# Altium schematic sheet uC_IO.SchDoc
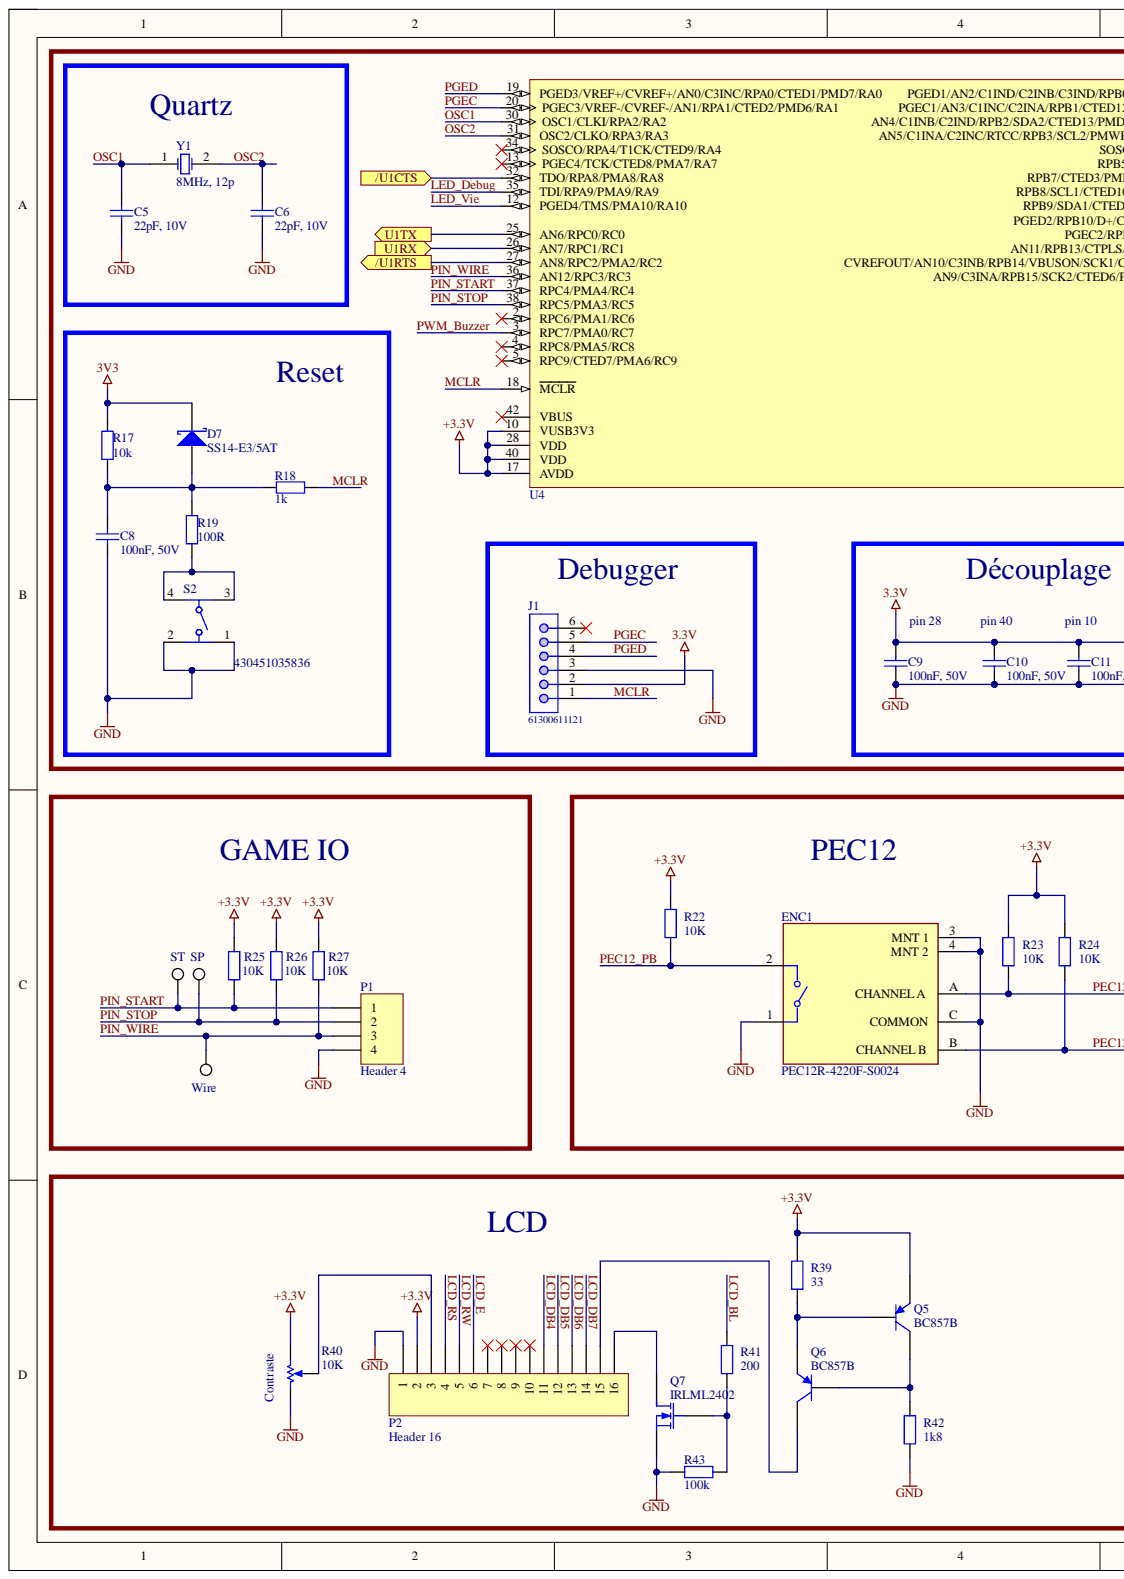
[diagram p0: uC_IO.SchDoc, left]
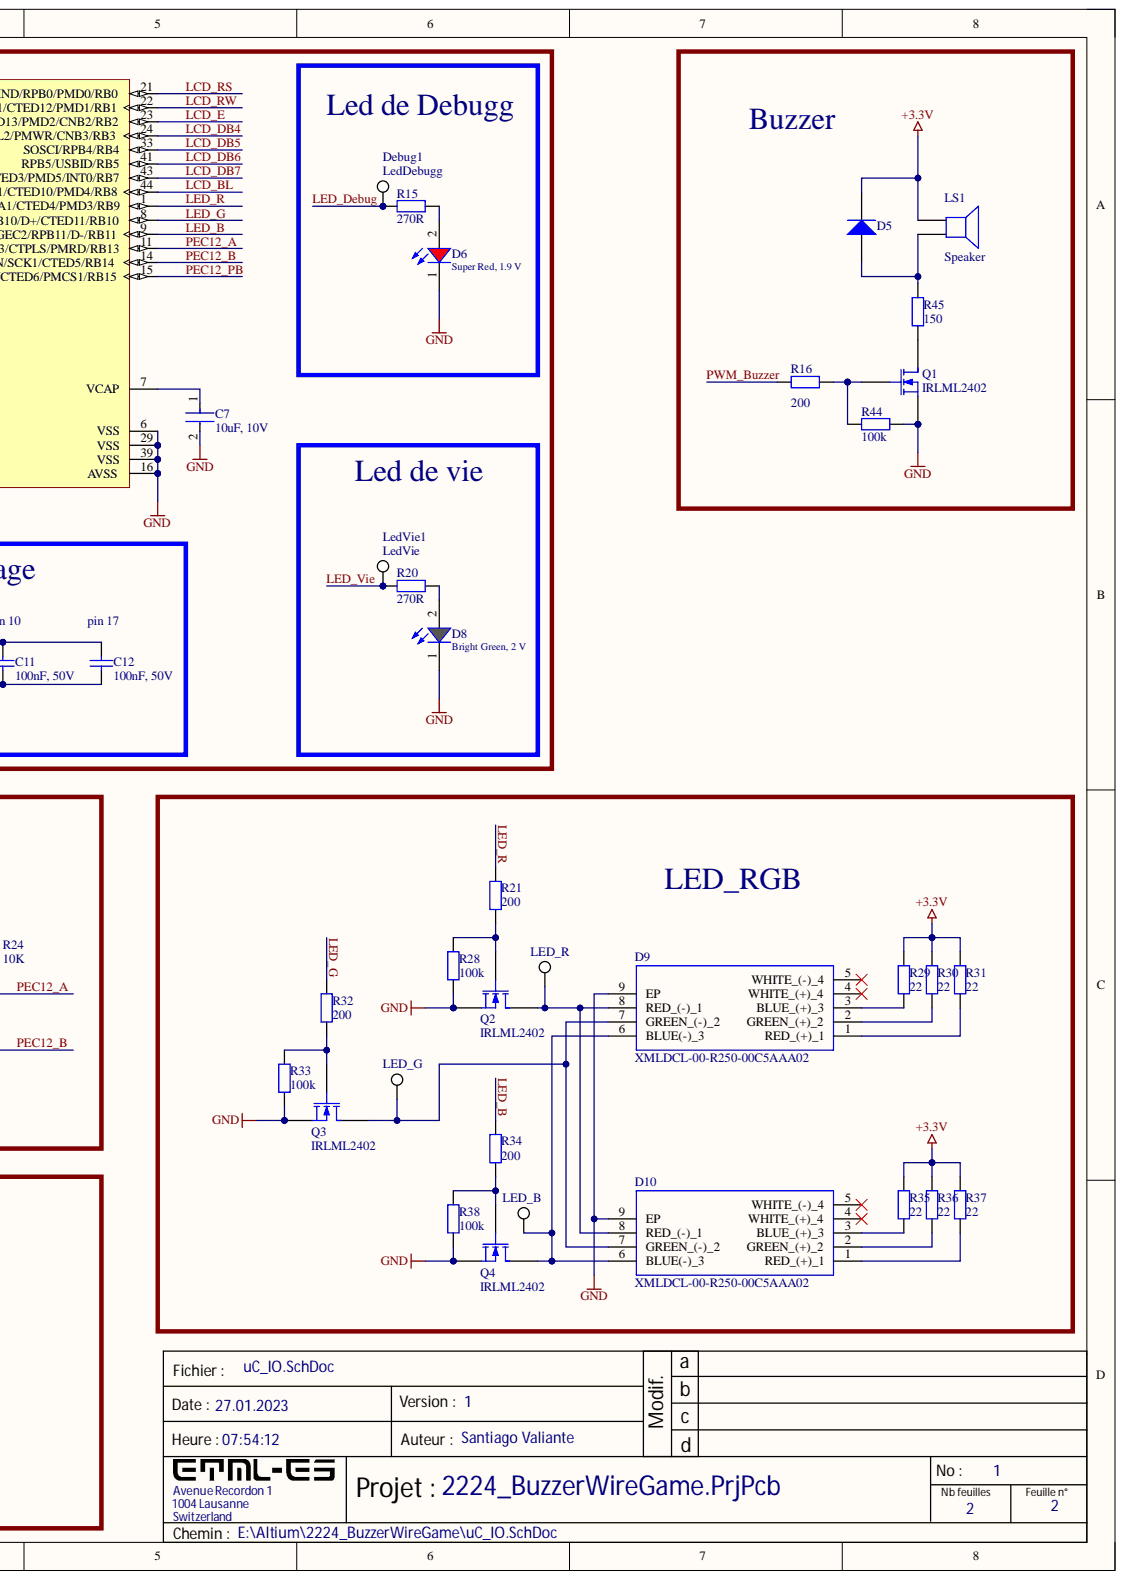
[diagram p1: uC_IO.SchDoc, right]

## Parts
C5  22pF, 10V  [CAPC2012M]
C6  22pF, 10V  [CAPC2012M]
C7  10uF, 10V  Voltage: 10V  [CAPC2012M]
C8  100nF, 50V  [CAPC2012M]
C9  100nF, 50V  [CAPC2012M]
C10  100nF, 50V  [CAPC2012M]
C11  100nF, 50V  [CAPC2012M]
C12  100nF, 50V  [CAPC2012M]
D5  Diode 1N4007  [DO-41]  (value not drawn)
D6  Super Red, 1.9 V  [WL-SMCW_0805]
D7  SS14-E3/5AT  [VISH-DO-214AC-2_V]
D8  Bright Green, 2 V  [WL-SMCW_0805]
D9  XMLDCL-00-R250-00C5AAA02  [XMLDCL00R25000C5AAA02]
D10  XMLDCL-00-R250-00C5AAA02  [XMLDCL00R25000C5AAA02]
Debug1  LedDebugg  [KSTN-5019_V]
ENC1  PEC12R-4220F-S0024  [FP-PEC12R-4220F-S0024-MFG]
J1  61300611121  [61300611121]
LED_B  Vbat  [KSTN-5019_V]  (value not drawn)
LED_G  Vbat  [KSTN-5019_V]  (value not drawn)
LED_R  Vbat  [KSTN-5019_V]  (value not drawn)
LedVie1  LedVie  [KSTN-5019_V]
LS1  Speaker  [PIN2]
P1  Header 4  [HDR1X4]
P2  Header 16  [HDR1X16]
Q1  IRLML2402  [E3]
Q2  IRLML2402  [E3]
Q3  IRLML2402  [E3]
Q4  IRLML2402  [E3]
Q5  BC857B  [SOT-23B_N]
Q6  BC857B  [SOT-23B_N]
Q7  IRLML2402  [E3]
R15  270R  [RESC2012M]
R16  value 200  [AXIAL-0.4]  (hidden comment: Res2)
R17  10k  [RESC2012M]
R18  1k  [RESC2012M]
R19  100R  [RESC2012M]
R20  270R  [RESC2012M]
R21  value 200  [AXIAL-0.4]  (hidden comment: Res2)
R22  value 10K  [AXIAL-0.4]  (hidden comment: Res2)
R23  value 10K  [AXIAL-0.4]  (hidden comment: Res2)
R24  value 10K  [AXIAL-0.4]  (hidden comment: Res2)
R25  value 10K  [AXIAL-0.4]  (hidden comment: Res2)
R26  value 10K  [AXIAL-0.4]  (hidden comment: Res2)
R27  value 10K  [AXIAL-0.4]  (hidden comment: Res2)
R28  value 100k  [AXIAL-0.4]  (hidden comment: Res2)
R29  value 22  [AXIAL-0.4]  (hidden comment: Res2)
R30  value 22  [AXIAL-0.4]  (hidden comment: Res2)
R31  value 22  [AXIAL-0.4]  (hidden comment: Res2)
R32  value 200  [AXIAL-0.4]  (hidden comment: Res2)
R33  value 100k  [AXIAL-0.4]  (hidden comment: Res2)
R34  value 200  [AXIAL-0.4]  (hidden comment: Res2)
R35  value 22  [AXIAL-0.4]  (hidden comment: Res2)
R36  value 22  [AXIAL-0.4]  (hidden comment: Res2)
R37  value 22  [AXIAL-0.4]  (hidden comment: Res2)
R38  value 100k  [AXIAL-0.4]  (hidden comment: Res2)
R39  value 33  [AXIAL-0.4]  (hidden comment: Res2)
R40  value 10K  [VR5]  (hidden comment: RPot)
R41  value 200  [AXIAL-0.4]  (hidden comment: Res2)
R42  value 1k8  [AXIAL-0.4]  (hidden comment: Res2)
R43  value 100k  [AXIAL-0.4]  (hidden comment: Res2)
R44  value 100k  [AXIAL-0.4]  (hidden comment: Res2)
R45  value 150  [AXIAL-0.4]  (hidden comment: Res2)
S2  430451035836  [430451035836]
SP  Vbat  [KSTN-5019_V]  (value not drawn)
ST  Vbat  [KSTN-5019_V]  (value not drawn)
U4  PIC32MX250F128D-I/PT  [MCHP-TQFP-PT44_M]  (value not drawn)
Wire  Vbat  [KSTN-5019_V]  (value not drawn)
Y1  8MHz, 12p  [FOX-FOXSDLF160-20_V]

## Ports
/U1CTS, /U1RTS, U1RX, U1TX

## Nets
3.3V: C9.1 C10.1 C11.1 C12.1 D5.2 J1.2 LS1.1 P2.2 Q5.3 R22.2 R23.2 R24.2 R25.2 R26.2 R27.2 R29.1 R30.1 R31.1 R35.1 R36.1 R37.1 R39.2 R40.1 U4.10(VUSB3V3) U4.17(AVDD) U4.28(VDD) U4.40(VDD)
3V3: D7.2 R17.2
GND: C5.1 C6.1 C7.2 C8.2 C9.2 C10.2 C11.2 C12.2 D6.1 D8.1 D9.9(EP) D10.9(EP) ENC1.1 ENC1.3(MNT_1) ENC1.4(MNT_2) ENC1.C(COMMON) J1.3 P1.4 P2.1 Q1.3 Q2.3 Q3.3 Q4.3 Q7.3 R28.2 R33.2 R38.2 R40.2 R42.1 R43.2 R44.2 S2.1 S2.2 U4.6(VSS) U4.16(AVSS) U4.29(VSS) U4.39(VSS)
/U1CTS: U4.32(TDO/RPA8/PMA8/RA8) ; port /U1CTS
/U1RTS: U4.27(AN8/RPC2/PMA2/RC2) ; port /U1RTS
LCD_BL: R41.2 U4.44(RPB8/SCL1/CTED10/PMD4/RB8)
LCD_DB4: P2.11 U4.24(AN5/C1INA/C2INC/RTCC/RPB3/SCL2/PMWR/CNB3/RB3)
LCD_DB5: P2.12 U4.33(SOSCI/RPB4/RB4)
LCD_DB6: P2.13 U4.41(RPB5/USBID/RB5)
LCD_DB7: P2.14 U4.43(RPB7/CTED3/PMD5/INT0/RB7)
LCD_E: P2.6 U4.23(AN4/C1INB/C2IND/RPB2/SDA2/CTED13/PMD2/CNB2/RB2)
LCD_RS: P2.4 U4.21(PGED1/AN2/C1IND/C2INB/C3IND/RPB0/PMD0/RB0)
LCD_RW: P2.5 U4.22(PGEC1/AN3/C1INC/C2INA/RPB1/CTED12/PMD1/RB1)
LED_B: R34.2 U4.9(PGEC2/RPB11/D-/RB11)
LED_Debug: Debug1.1 R15.2 U4.35(TDI/RPA9/PMA9/RA9)
LED_G: R32.2 U4.8(PGED2/RPB10/D+/CTED11/RB10)
LED_R: R21.2 U4.1(RPB9/SDA1/CTED4/PMD3/RB9)
LED_Vie: LedVie1.1 R20.2 U4.12(PGED4/TMS/PMA10/RA10)
MCLR: J1.1 R18.2 U4.18(MCLR)
OSC1: C5.2 U4.30(OSC1/CLKI/RPA2/RA2) Y1.1
OSC2: C6.2 U4.31(OSC2/CLKO/RPA3/RA3) Y1.2
PEC12_A: ENC1.A(CHANNEL_A) R23.1 U4.11(AN11/RPB13/CTPLS/PMRD/RB13)
PEC12_B: ENC1.B(CHANNEL_B) R24.1 U4.14(CVREFOUT/AN10/C3INB/RPB14/VBUSON/SCK1/CTED5/RB14)
PEC12_PB: ENC1.2 R22.1 U4.15(AN9/C3INA/RPB15/SCK2/CTED6/PMCS1/RB15)
PGEC: J1.5 U4.20(PGEC3/VREF-/CVREF-/AN1/RPA1/CTED2/PMD6/RA1)
PGED: J1.4 U4.19(PGED3/VREF+/CVREF+/AN0/C3INC/RPA0/CTED1/PMD7/RA0)
PIN_START: P1.1 R25.1 ST.1 U4.37(RPC4/PMA4/RC4)
PIN_STOP: P1.2 R26.1 SP.1 U4.38(RPC5/PMA3/RC5)
PIN_WIRE: P1.3 R27.1 U4.36(AN12/RPC3/RC3) Wire.1
PWM_Buzzer: R16.1 U4.3(RPC7/PMA0/RC7)
U1RX: U4.26(AN7/RPC1/RC1) ; port U1RX
U1TX: U4.25(AN6/RPC0/RC0) ; port U1TX
NetC7_1: C7.1 U4.7(VCAP)
NetC8_1: C8.1 D7.1 R17.1 R18.1 R19.2
NetD5_1: D5.1 LS1.2 R45.1
NetD6_2: D6.2 R15.1
NetD8_2: D8.2 R20.1
NetD9_1: D9.1(RED_(+)_1) R31.2
NetD9_2: D9.2(GREEN_(+)_2) R30.2
NetD9_3: D9.3(BLUE_(+)_3) R29.2
NetD9_6: D9.6(BLUE(-)_3) D10.6(BLUE(-)_3) LED_B.1 Q4.1
NetD9_7: D9.7(GREEN_(-)_2) D10.7(GREEN_(-)_2) LED_G.1 Q3.1
NetD9_8: D9.8(RED_(-)_1) D10.8(RED_(-)_1) LED_R.1 Q2.1
NetD10_1: D10.1(RED_(+)_1) R37.2
NetD10_2: D10.2(GREEN_(+)_2) R36.2
NetD10_3: D10.3(BLUE_(+)_3) R35.2
NetP2_3: P2.3 R40.3
NetP2_15: P2.15 Q6.1
NetP2_16: P2.16 Q7.1
NetQ1_1: Q1.1 R45.2
NetQ1_2: Q1.2 R16.2 R44.1
NetQ2_2: Q2.2 R21.1 R28.1
NetQ3_2: Q3.2 R32.1 R33.1
NetQ4_2: Q4.2 R34.1 R38.1
NetQ5_1: Q5.1 Q6.2 R42.2
NetQ5_2: Q5.2 Q6.3 R39.1
NetQ7_2: Q7.2 R41.1 R43.1
NetR19_1: R19.1 S2.3 S2.4

Not connected: D9 4(WHITE_(+)_4) 5(WHITE_(-)_4); D10 4(WHITE_(+)_4) 5(WHITE_(-)_4); J1 6; P2 7 8 9 10; U4 2(RPC6/PMA1/RC6) 4(RPC8/PMA5/RC8) 5(RPC9/CTED7/PMA6/RC9) 13(PGEC4/TCK/CTED8/PMA7/RA7) 34(SOSCO/RPA4/T1CK/CTED9/RA4) 42(VBUS)

## Library data of the parts
C5 C6 = CMP-1036-01211-1: CAP 22pF 10V ±10% 0805 (2012 Metric) Thickness 0.75mm SMD; Case-EIA: 0805; Case-Metric: 2012; Tolerance: Â±10%; Component Kind: Standard; Max Thickness: 0.75 mm; Rated Voltage: 10 V; Technology: SMT; Value: 22pF; Library Name: 2121_Robot3roues_V2.0.SCHLIB
C7 = CMP-2007-03243-2: CAP CER 10UF 10V X5R 0805; 3DS Part Number: 220-00001; Manufacturer: Kemet; Manufacturer Part Number: C0805C106K8PACTU; Material: Ceramic; Temperature Coefficient: 15%; Tolerance: 10%; Automotive: No; Capacitance: 10uF; Category: Cap; Component Kind: Standard; ComponentLink2Description: Datasheet; ComponentLink2URL: https://content.kemet.com/datasheets/KEM_C1006_X5R_SMD.pdf; Device Class L1: Passive Components; Device Class L2: Capacitors; Device Class L3: Ceramic Capacitors; Digikey Description: CAP CER 10UF 10V X5R 0805; Height: 1.4mm; Ipc Land Pattern Name: CAPC200125X125; Lead Free: Yes; Mouser Description: CAP CER 10UF 10V X5R 0805; Octopart: https://octopart.com/c0805c106k8pactu-kemet-98697; Package: C0805C-DG; RoHS: TRUE; Temperature: -55°C to +85°C; Temperature Characteristic: X5R; Temperature Range High: +85°C; Temperature Range Low: -55°C; Value: 10uF; Voltage Rating: 10V; Library Name: 2121_Robot3roues_V2.0.SCHLIB
C8-C12 = 885012207098: WCAP-CSGP General Purpose Ceramic Capacitors, size 0805, 100nF, +/-10%, 50VDC; Ceramic Type: X7R; Dissipation Factor: #NAME?; Isolation Resistance: >=5GOhm; Technical Reference: X7R0805104K050DFCT10000; Capacitance: 100nF; Capacitance Tolerance: +/-10%; Component Kind: Standard; ComponentLink1Description: Manufacturer; ComponentLink1URL: http://www.we-online.de; ComponentLink2Description: Datasheet; ComponentLink2URL: https://katalog.we-online.com/pbs/datasheet/885012207098.pdf; Height: 0.8mm +/-0.10mm; Mounting Technology: SMD; Operating Temperature: -55 to +125degC; Packaging: Tape and Reel; Part Number: 885012207098; Published Date: August 2019; Rated Voltage: 50VDC; Size: 0805; Supplier 1: Digi-Key; Supplier 2: Distrelec; Supplier Part Number 1: 732-8080-1-ND; Supplier Part Number 2: 30067953; Library Name: VPA_v2b.SCHLIB; Publisher: Wurth Elektronik
D5 = Diode 1N4007: 1 Amp General Purpose Rectifier; Code_JEDEC: DO-204-AL; Component Kind: Standard; ComponentLink1Description: Manufacturer Link; ComponentLink1URL: http://www.fairchildsemi.com/; ComponentLink2Description: Datasheet; ComponentLink2URL: http://www.fairchildsemi.com/ds/1N%2F1N4001.pdf; DatasheetVersion: 1998; LatestRevisionDate: 17-Jul-2002; LatestRevisionNote: Re-released for DXP Platform.; Library Name: Miscellaneous Devices.IntLib; PackageDescription: Diode, Axial; Body 5.2 x 2.7 mm (LxDia. max), Lead Dia. 0.86 mm (max); PackageReference: DO-41; PackageVersion: Aug-1999; Published: 8-Jun-2000; Signal Integrity: 1N4007; Simulation: 1N4007; Publisher: Altium Limited
D6 = 150080SS75000: SMT Mono-color Chip LED Waterclear WL-SMCW, size 0805, Super Red, 1.9 V, 140 deg, 60 mcd; Chip Technology: AlInGaP; Dominant Wavelength: 630 nm; Forward Voltage: 1.9 V; Luminous Intensity: 60 mcd; Peak Wavelength: 645 nm; Viewing Angle: 140 deg; Component Kind: Standard; ComponentLink1Description: Manufacturer; ComponentLink1URL: http://www.we-online.de; ComponentLink2Description: Datasheet; ComponentLink2URL: https://katalog.we-online.de/led/datasheet/150080SS75000.pdf; Emitting Color: Super Red; Mounting Technology: SMT; Operating Temperature: -40 to 85 degC; Packaging: Tape and Reel; Part Number: 150080SS75000; Published Date: November 2018; Size: 0805; Supplier 1: Digi-Key; Supplier 2: Distrelec; Supplier Part Number 1: 732-4985-1-ND; Supplier Part Number 2: 30008875; Library Name: VPA_v2b.SCHLIB; Publisher: Wurth Elektronik
D7 = CMP-0549-00004-1: Schottky Barrier Rectifier, 40 V Maximum DC Blocking Voltage, -65 to 125 degC, 2-Pin SMA Case, RoHS; Manufacturer: Vishay Semiconductor; IF(AV): 1 A Max.; IFSM: 40 A; VDC: 40 V Max.; VRMS: 28 V Max.; Component Kind: Standard; ComponentLink1Description: Manufacturer URL; ComponentLink1URL: http://www.vishay.com/; ComponentLink2Description: Datasheet; ComponentLink2URL: http://www.vishay.com/docs/88746/ss12.pdf; ComponentLink3Description: Package Specification; ComponentLink3URL: http://www.vishay.com/docs/88746/ss12.pdf; DatasheetVersion: 12/17/2012; Library Name: Altium Content Vault; Mounting Technology: Surface Mount; Operating Temperature: -65 to 125 degC; PackageDescription: 2-Pin SMD, Body 4.245 x 2.665 mm, Height 2.29 mm; PackageReference: DO-214AC-2; PackageVersion: Dec-12; PartNumber: SS14-E3/5AT; RoHS: TRUE; VRRM: 40 V Max.
D8 = 150080VS75000: SMT Mono-color Chip LED Waterclear WL-SMCW, size 0805, Bright Green, 2 V, 140 deg, 40 mcd; Chip Technology: AlInGaP; Dominant Wavelength: 570 nm; Forward Voltage: 2 V; Luminous Intensity: 40 mcd; Peak Wavelength: 572 nm; Viewing Angle: 140 deg; Component Kind: Standard; ComponentLink1Description: Manufacturer; ComponentLink1URL: http://www.we-online.de; ComponentLink2Description: Datasheet; ComponentLink2URL: https://katalog.we-online.de/led/datasheet/150080VS75000.pdf; Emitting Color: Bright Green; Mounting Technology: SMT; Operating Temperature: -40 to 85 degC; Packaging: Tape and Reel; Part Number: 150080VS75000; Published Date: November 2018; Size: 0805; Supplier 1: Digi-Key; Supplier 2: Distrelec; Supplier Part Number 1: 732-4986-1-ND; Supplier Part Number 2: 30008876; Library Name: VPA_v2b.SCHLIB; Publisher: Wurth Elektronik
D9 D10 = XMLDCL-00-R250-00C5AAA02: High Power LEDs - Multi-Colour RGB, White 6000K LED; Manufacturer_Name: Wolfspeed; Geometry.Height: 3.15mm; Component Kind: Standard; Datasheet Link: https://eu.mouser.com/datasheet/2/723/XLamp_XMLDCL-2935899.pdf; Manufacturer_Part_Number: XMLDCL-00-R250-00C5AAA02; Mouser Part Number: 941-XMLDCL0R25C5A02; Mouser Price/Stock: https://www.mouser.co.uk/ProductDetail/Cree-LED/XMLDCL-00-R250-00C5AAA02?qs=Znm5pLBrcALryTgji8xmYQ%3D%3D; Library Name: Projet_Lampe_V1.SCHLIB
Debug1 LED_B LED_G LED_R LedVie1 SP ST Wire = CMP-1672-00008-1: Test Point, 1 Position SMD, RoHS, Tape and Reel; Component Kind: Standard; ComponentLink1Description: Manufacturer URL; ComponentLink1URL: http://www.keyelco.com/; ComponentLink2Description: Datasheet; ComponentLink2URL: http://www.keyelco.com/product-pdf.cfm?p=1357; Contact Plating: Silver; DatasheetVersion: 03/2014; Library Name: Altium Content Vault; Mount: Surface Mount; Mounting Technology: Surface Mount; Package Quantity: 1000; PackageDescription: 1-Pin Surface Mount Device, Body 3.81 x 2.03 mm; PackageReference: 5019; Packaging: Tape and Reel; Pins: 1; RoHSCompliant: True
ENC1 = CMP-07421-000021-1: ROTARY ENCODER MECHANICAL 24PPR; Built in Switch: Yes; Hole Diameter: 2mm; Max Supply Voltage (DC): 5V; Number of Channels: 2; Output Type: Quadrature; Pulses per Revolution: 24; Component Kind: Standard; Detent: Yes; Encoder Type: Mechanical; Height: 13.4mm; Length: 26mm; Library Name: Altium Content Vault; Max Operating Temperature: 70°C; Min Operating Temperature: -30°C; Mount: Through Hole; Orientation: Vertical; Package Quantity: 150; Packaging: Bulk; REACH SVHC: No SVHC; RoHS Compliant: Yes; Series: PEC12R; Termination: PC Pin; Width: 12.5mm
J1 = 61300611121: WR-PHD Pin Header, THT, pitch 2.54mm, Single Row, Vertical, 6pin; Contact Resistance: 20mOhm; Gender: Male; Pin Number: 6; Rated Current: 3A; Withstanding Voltage: 500VAC; Working Voltage: 250VAC; Component Kind: Standard; ComponentLink1Description: Manufacturer; ComponentLink1URL: http://www.we-online.de; ComponentLink2Description: Datasheet; ComponentLink2URL: https://katalog.we-online.de/em/datasheet/6130xx11121.pdf; Mounting Technology: THT; Operating Temperature: -40 to +105degC; Orientation: Vertical; Packaging: Bag; Part Number: 61300611121; Pitch: 2.54mm; Published Date: June 2019; Row Number: Single; Supplier 1: Digi-Key; Supplier 2: Distrelec; Supplier Part Number 1: 732-5319-ND; Supplier Part Number 2: 30024526; Library Name: VPA_v2b.SCHLIB; Publisher: Wurth Elektronik
LS1 = Speaker: Loudspeaker; Component Kind: Standard; LatestRevisionDate: 17-Jul-2002; LatestRevisionNote: Re-released for DXP Platform.; Library Name: Miscellaneous Devices.IntLib; PackageReference: PIN2; Published: 24-Mar-1999; Publisher: Altium Limited
P1 = Header 4: Header, 4-Pin; Component Kind: Standard; LatestRevisionDate: 17-Jul-2002; LatestRevisionNote: Re-released for DXP Platform.; Library Name: Miscellaneous Connectors.IntLib; Signal Integrity: Connector; Publisher: Altium Limited
P2 = Header 16: Header, 16-Pin; Component Kind: Standard; LatestRevisionDate: 17-Jul-2002; LatestRevisionNote: Re-released for DXP Platform.; Library Name: Miscellaneous Connectors.IntLib; Signal Integrity: Connector; Publisher: Altium Limited
Q1-Q4 Q7 = MOSFET-N: N-Channel MOSFET; Component Kind: Standard; LatestRevisionDate: 17-Jul-2002; LatestRevisionNote: Re-released for DXP Platform.; Library Name: Miscellaneous Devices.IntLib; PackageDescription: TO, Flat Index; 3 In-Line, Axial Leads; Body Dia. 4.6mm; Lead Dia. 0.5 mm (max); PackageReference: E3; PackageVersion: 1995/1996; Published: 8-Jun-2000; Simulation: NMOS; Publisher: Altium Limited
Q5 Q6 = PNP: PNP Bipolar Transistor; Component Kind: Standard; LatestRevisionDate: 29-May-2009; LatestRevisionNote: IPC-7351 Footprint Added.; Library Name: Miscellaneous Devices.IntLib; PackageDescription: 3-Pin SOT-23 Package 0.95 mm Pitch, 2.4 mm Lead Span; PackageReference: SOT-23B; PackageVersion: Aug-1999; Published: 8-Jun-2000; Signal Integrity: PNP; Simulation: PNP; Publisher: Altium Limited
R15 R20 = CMP-2001-00528-1: Manufacturer: Panasonic; Part Number: ERJ6ENF2703V; Component Kind: Standard; Library Name: 2121_Robot3roues_V2.0.SCHLIB
R16 R21-R39 R41-R45 = Res2: Resistor; Component Kind: Standard; LatestRevisionDate: 17-Jul-2002; LatestRevisionNote: Re-released for DXP Platform.; Library Name: Miscellaneous Devices.IntLib; PackageDescription: Axial Device, Thru-Hole; 2 Leads; 0.4 in Pin Spacing; PackageReference: AXIAL-0.4; Published: 8-Jun-2000; Signal Integrity: Res; Simulation: RESISTOR; Publisher: Altium Limited
R17 = CMP-2001-04376-1: Manufacturer: Panasonic; Part Number: ERJ-6ENF1002V; Component Kind: Standard; Library Name: 2121_Robot3roues_V2.0.SCHLIB
R18 = CMP-1013-00510-2: Manufacturer: Vishay Dale; Part Number: CRCW08051K00FKEA; Component Kind: Standard; Library Name: 2121_Robot3roues_V2.0.SCHLIB
R19 = CMP-2001-04723-1: Manufacturer: Vishay Dale; Part Number: TNPW0805100RBEEA; Component Kind: Standard; Library Name: 2121_Robot3roues_V2.0.SCHLIB
R40 = RPot: Potentiometer; Set Position: 0.5; Sim Note: Enter the Resistance value and the set point into parameter fields.; Component Kind: Standard; LatestRevisionDate: 17-Jul-2002; LatestRevisionNote: Re-released for DXP Platform.; Library Name: Miscellaneous Devices.IntLib; PackageDescription: Variable Resistor, Thru-Hole; 3 Leads; PackageReference: VR5; Published: 8-Jun-2000; Simulation: POT; Publisher: Altium Limited
S2 = 430451035836: WS-TASV SMT Washable Tact Switch 6x6mm, height 3.5mm, 360gf; Rated Current: 50mA; Rated Voltage: 12VDC; Washable: Yes; Component Kind: Standard; ComponentLink1Description: Manufacturer; ComponentLink1URL: http://www.we-online.de; ComponentLink2Description: Datasheet; ComponentLink2URL: https://katalog.we-online.com/em/datasheet/430451035836.pdf; Contact Material: Stainless Steel; Contact Plating: Silver; Height: 3.5mm; Mounting Technology: SMT; Operating Temperature: -40 to +85degC; Operation Force: 360gf; Packaging: Tape and Reel; Part Number: 430451035836; Published Date: September 2019; Terminal Material: Brass; Terminal Plating: Silver; Library Name: VPA_v2b.SCHLIB; Publisher: Wurth Elektronik
U4 = CMP-0048-00499-1: 32-bit Microcontroller with Audio and Graphics Interface, USB and Advanced Analog, 50 MHz, 33 I/O, PMP, -40 to 85 degC, 44-pin TQFP (PT44), Tray; Manufacturer: Microchip; Component Kind: Standard; ComponentLink1Description: Manufacturer URL; ComponentLink1URL: http://www.microchip.com; ComponentLink2Description: Datasheet; ComponentLink2URL: http://ww1.microchip.com/downloads/en/DeviceDoc/61168E.pdf; ComponentLink3Description: Package Specification; ComponentLink3URL: http://www.microchip.com/stellent/groups/techpub_sg/documents/packagingspec/en012702.pdf; ComponentLink4Description: Product URL; ComponentLink4URL: http://www.microchip.com/PIC32MX250F128D; DatasheetVersion: Rev. E; Library Name: Altium Content Vault; Mounting Technology: Surface Mount; PackageDescription: 44-Lead Plastic Thin Quad Flatpack, 10 x 10 mm Body, 0.8 mm Pitch; PackageReference: TQFP-PT44; PackageVersion: C04-076B; PartNumber: PIC32MX250F128D-I/PT
Y1 = CMP-1749-00001-1: Resistance Weld SMD Crystal, 8 MHz, +/- 30 ppm, 12 pF, -20 to 70 degC, 2-Pin SMD, RoHS, Tape and Reel; Case\Package: SOT-553-6; Interface: I2C; Max Supply Voltage: 3.3 V; Max Supply Voltage (DC): 6 V; Min Supply Voltage: 1.4 V; Min Supply Voltage (DC): 4 V; Output Current: 3 mA; Value: 8M, 12p; Component Kind: Standard; ComponentLink1Description: Manufacturer URL; ComponentLink1URL: http://www.foxonline.com/; ComponentLink2Description: Datasheet; ComponentLink2URL: http://foxonline.com/pdfs/hc49sdlf.pdf; DatasheetVersion: Mar-15; Height: 0.55 mm; Length: 1.6 mm; Library Name: Altium Content Vault; Max Operating Temperature: 150 degC; Min Operating Temperature: -55 degC; Mount: Surface Mount; Package Quantity: 1; PackageDescription: 2-Pin Surface Mount Device, Body 5 x 11.7 mm, Height 4.5 mm; PackageReference: FOX-FOXSDLF160-20; Packaging: Cut Tape; Pins: 6; Radiation Hardening: No; REACH SVHC: No SVHC; RoHSCompliant: true; Weight: 9.9E-05 oz; Width: 1.2 mm
